# Altium schematic sheet ActiTouch.SchDoc(ActiTouch)
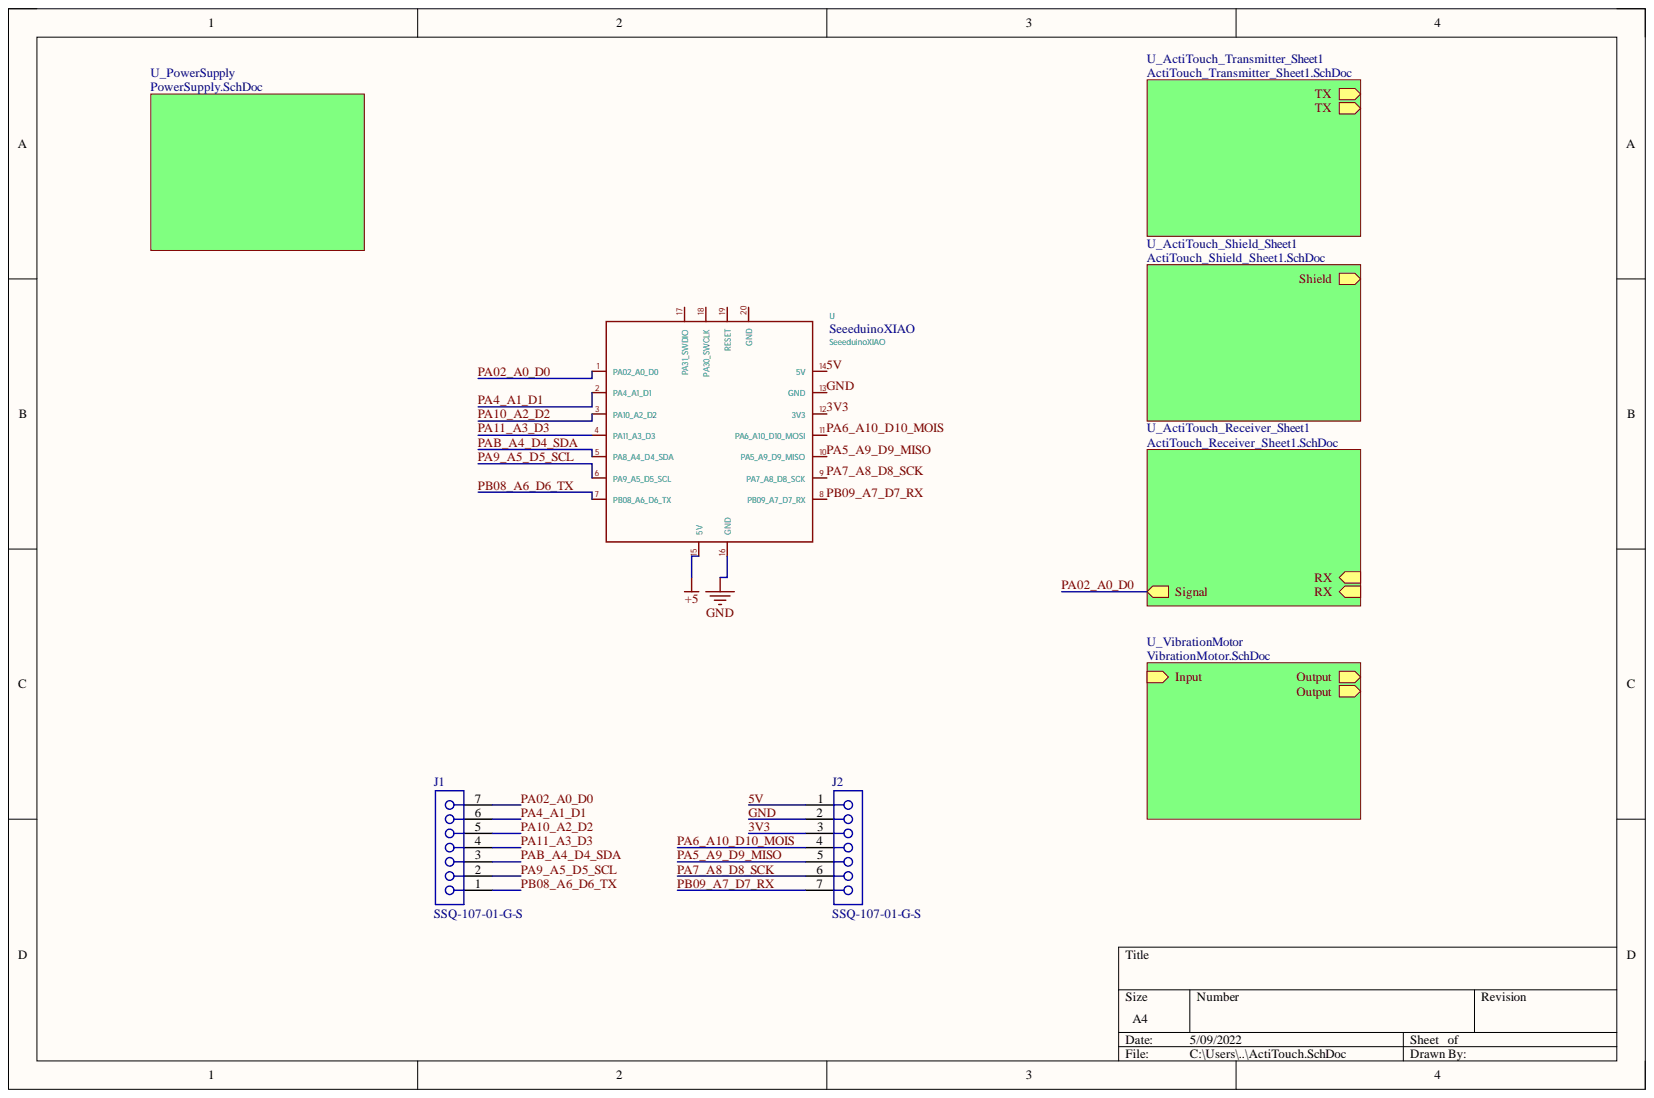
[diagram p0: ActiTouch.SchDoc]

## Parts
J1  SSQ-107-01-G-S  [SMTC-SSQ-107-01-X-S]
J2  SSQ-107-01-G-S  [SMTC-SSQ-107-01-X-S]
U  SeeeduinoXIAO

## Nets
3V3: J2.3 U.12(3V3)
5V: J2.1 U.14(5V) U.15(5V)
GND: J2.2 U.13(GND) U.16(GND)
PA02_A0_D0: J1.7 U.1(PA02_A0_D0)
PA4_A1_D1: J1.6 U.2(PA4_A1_D1)
PA5_A9_D9_MISO: J2.5 U.10(PA5_A9_D9_MISO)
PA6_A10_D10_MOIS: J2.4 U.11(PA6_A10_D10_MOSI)
PA7_A8_D8_SCK: J2.6 U.9(PA7_A8_D8_SCK)
PA9_A5_D5_SCL: J1.2 U.6(PA9_A5_D5_SCL)
PA10_A2_D2: J1.5 U.3(PA10_A2_D2)
PA11_A3_D3: J1.4 U.4(PA11_A3_D3)
PAB_A4_D4_SDA: J1.3 U.5(PA8_A4_D4_SDA)
PB08_A6_D6_TX: J1.1 U.7(PB08_A6_D6_TX)
PB09_A7_D7_RX: J2.7 U.8(PB09_A7_D7_RX)
NetU_17: U.17(PA31_SWDIO)
NetU_18: U.18(PA30_SWCLK)
NetU_19: U.19(RESET)
NetU_20: U.20(GND)
Input:
Output:
RX:
Shield:
TX:

## Library data of the parts
J1 J2 = CMP-1023-00284-1: SQ Post Socket, Through-hole, Vertical, -55 to 125 degC, 2.54 mm Pitch, 7-Pin, Female, RoHS; Manufacturer: Samtec; Pitch: 2.54 mm; Component Kind: Standard; ComponentLink1Description: Manufacturer URL; ComponentLink1URL: http://www.samtec.com; ComponentLink2Description: Datasheet; ComponentLink2URL: http://www.samtec.com/documents/webfiles/pdf/SSQ_TH.PDF; ComponentLink3Description: Package Specification; ComponentLink3URL: http://www.samtec.com/documents/webfiles/cpdf/SSQ-1XX-XX-XXX-X-XX-XXX-XX-X-MKT.pdf; DatasheetVersion: F-214; Library Name: Altium Content Vault; Mounting Technology: Through-hole; Orientation: Vertical; PackageDescription: Assembly, Socket Strip .025[0.64] SQ Tail; PackageReference: SSQ-107-01-X-S; PackageVersion: BD, 6/1987; PartNumber: SSQ-107-01-G-S; RoHS: True; Temperature Range: -55 to 125 degC
U = SeeeduinoXIAO: Component Kind: Standard; Library Name: Seeeduino XIAO.SchLib; Value: SeeeduinoXIAO
